# Altium schematic sheet LCD_Interface.SchDoc
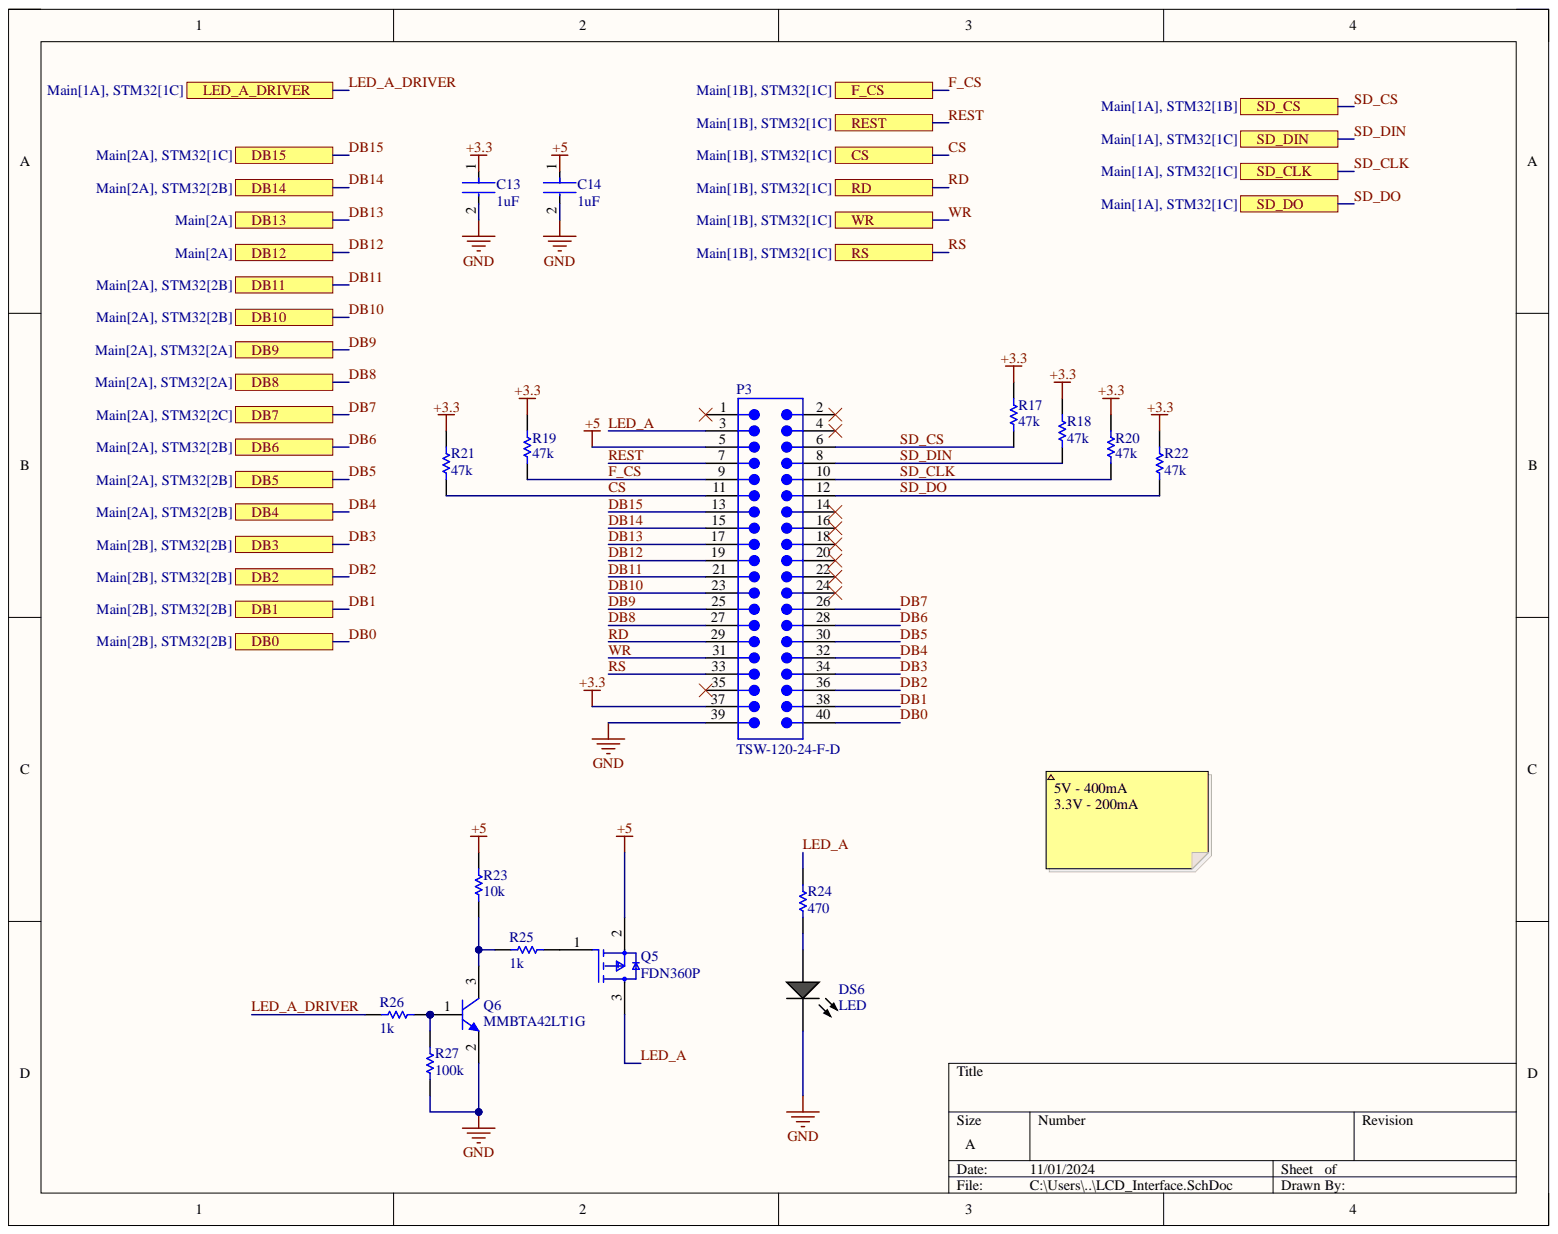
[diagram p0: LCD_Interface.SchDoc]

## Parts
C13  1uF  [FP-0805-L_2_01_0_2-W_1_25-IPC_B]
C14  1uF  [FP-0805-L_2_01_0_2-W_1_25-IPC_B]
DS6  LED  [AVAG-HSMX-C170_V]
P3  TSW-120-24-F-D  [SMTC-TSW-120-24-X-D]
Q5  FDN360P  [FP-527AG-IPC_B]
Q6  MMBTA42LT1G  [ONSC-SOT-23-3-318-08_V]
R17  47k  [RESC2013X50X30LL20T20]
R18  47k  [RESC2013X50X30LL20T20]
R19  47k  [RESC2013X50X30LL20T20]
R20  47k  [RESC2013X50X30LL20T20]
R21  47k  [RESC2013X50X30LL20T20]
R22  47k  [RESC2013X50X30LL20T20]
R23  10k  [RESC2013X50X30LL20T20]
R24  470  [RESC2013X50X30LL20T20]
R25  1k  [RESC2013X50X30LL20T20]
R26  1k  [RESC2013X50X30LL20T20]
R27  100k  [RESC2013X50X30LL20T20]

## Ports
CS, DB0, DB1, DB2, DB3, DB4, DB5, DB6, DB7, DB8, DB9, DB10, DB11, DB12, DB13, DB14, DB15, F_CS, LED_A_DRIVER, RD, REST, RS, SD_CLK, SD_CS, SD_DIN, SD_DO, WR

## Nets
+3.3: C13.1 P3.37 R17.2 R18.2 R19.2 R20.2 R21.2 R22.2
+5: C14.1 P3.5 Q5.2 R23.2
GND: C13.2 C14.2 DS6.2 P3.39 Q6.2 R27.1
CS: P3.11 R21.1 ; port CS
DB0: P3.40 ; port DB0
DB1: P3.38 ; port DB1
DB2: P3.36 ; port DB2
DB3: P3.34 ; port DB3
DB4: P3.32 ; port DB4
DB5: P3.30 ; port DB5
DB6: P3.28 ; port DB6
DB7: P3.26 ; port DB7
DB8: P3.27 ; port DB8
DB9: P3.25 ; port DB9
DB10: P3.23 ; port DB10
DB11: P3.21 ; port DB11
DB12: P3.19 ; port DB12
DB13: P3.17 ; port DB13
DB14: P3.15 ; port DB14
DB15: P3.13 ; port DB15
F_CS: P3.9 R19.1 ; port F_CS
LED_A: P3.3 Q5.3 R24.2
LED_A_DRIVER: R26.1 ; port LED_A_DRIVER
RD: P3.29 ; port RD
REST: P3.7 ; port REST
RS: P3.33 ; port RS
SD_CLK: P3.10 R20.1 ; port SD_CLK
SD_CS: P3.6 R17.1 ; port SD_CS
SD_DIN: P3.8 R18.1 ; port SD_DIN
SD_DO: P3.12 R22.1 ; port SD_DO
WR: P3.31 ; port WR
NetDS6_1: DS6.1 R24.1
NetP3_1: P3.1
NetP3_2: P3.2
NetP3_4: P3.4
NetP3_14: P3.14
NetP3_16: P3.16
NetP3_18: P3.18
NetP3_20: P3.20
NetP3_22: P3.22
NetP3_24: P3.24
NetP3_35: P3.35
NetQ5_1: Q5.1 R25.2
NetQ6_1: Q6.1 R26.2 R27.2
NetQ6_3: Q6.3 R23.1 R25.1

## Library data of the parts
C13 C14 = CMP-2007-04174-2: General Purpose Ceramic Capacitor, 0805, 100nF, 10%, X7R, 15%, 100V; Capacitance: 100nF; Case Code (Imperial): 0805; Case Code (Metric): 2012; Case/Package: 0805; Component Kind: Standard; Construction: Flat; Contact Plating: Tin; Depth: 1.25mm; Dielectric: X7R; Dielectric Material: Ceramic; Height: 1.4mm; Lead Free: Lead Free; Length: 2.01mm; Library Name: Altium Content Vault; Max Operating Temperature: 125°C; Min Operating Temperature: -55°C; Mount: Surface Mount; Number of Pins: 2; Package Quantity: 2000; Packaging: Tape and Reel; Radiation Hardening: No; REACH SVHC: No SVHC; RoHS Compliant: Yes; Series: -; Termination: SMD/SMT; Thickness: 0.055inch; Tolerance: 10%; Voltage: 100V; Voltage Rating: 100V; Voltage Rating (DC): 100V; Width: 0.049inch
DS6 = CMP-2000-05062-1: Chip LED, Green, 2.2 V, -40 to 85 degC, 2-Pin SMD, Pb-Free, Tape and Reel; Manufacturer: Avago; Bulb Size: 1.25mmx1.4mm; Dominant Wavelength: 572nm; External Depth: 0.8mm; External Length / Height: 2mm; External Width: 1.25mm; Forward Current If: 20mA; Forward Voltage: 2.2V; Forward Voltage VF Max: 2.6V; LED Type: Standard; Lens Shape: Rectangular; Light Area Height: 1mm; Luminous Intensity: 15mcd; Luminous Intensity Min: 4mcd; No. of Pins: 2; Opto Mounting Orientation: Top; Peak Wavelength: 570nm; PIV Max: 5V; SVHC: NoSVHC(16-Jun-2014); Viewing Angle: 170deg; Component Kind: Standard; Datasheet URL: https://datasheet.ciiva.com/29280/180495-da-01-en-chip-led-hsmg-c170-gelb-gruen-29280971.pdf; Datasheet Version: Oct-08; Dominant Wavelength Typ: 572nm; Forward Current If Max: 20mA; LED Colour: Green; LED Mounting: SMD; Library Name: Altium Content Vault; Luminous Intensity Typ: 15mcd; Manufacturer URL: http://www.avagotech.com/; Mounting Type: SMD; MSL: MSL2A-4weeks; Operating Temperature Max: 85degC; Operating Temperature Min: -30degC; Operating Temperature Range: -30degCto+85degC; Opto Case Style: SMD; Package Description: 2-Pin Surface Mount Device, Body 2 x 1.25 mm, Height 0.8 mm; Package Reference: HSMX-C170; Packaging: CutTape; Peak Forward Current: 20mA; Storage Temperature Max: 85degC; Storage Temperature Min: -40degC; Voltage Vf Typ: 2.2V; Wavelength Typ: 570nm
P3 = CMP-1024-00453-1: 0.025" SQ Post Header, Through-hole, Vertical, -55 to 125 degC, 2.54 mm Pitch, 40-Pin, Male, RoHS; Manufacturer: Samtec; Pitch: 2.54 mm; Component Kind: Standard; ComponentLink1Description: Manufacturer URL; ComponentLink1URL: http://www.samtec.com; ComponentLink2Description: Datasheet; ComponentLink2URL: http://www.samtec.com/documents/webfiles/pdf/TSW_TH.PDF; ComponentLink3Description: Package Specification; ComponentLink3URL: http://www.samtec.com/documents/webfiles/cpdf/TSW-XXX-XX-XXX-X-XX-XXX-MKT.pdf; DatasheetVersion: F-214; Library Name: Altium Content Vault; Mounting Technology: Through-hole; Orientation: Vertical; PackageDescription: .025[0.64] SQ. Post Terminal Strip Assembly; PackageReference: TSW-120-24-X-D; PackageVersion: DM, 8/1988; PartNumber: TSW-120-24-F-D; RoHS: True; Temperature Range: -55 to 125 degC
Q5 = CMP-2000-07455-3: MOSFET P-CH 30V 2A SSOT3; Current Rating: -2A; Drain to Source Breakdown Voltage: -30V; Drain to Source Resistance: 63mR; Element Configuration: Single; Fall Time: 6ns; Gate to Source Voltage (Vgs): 20V; Input Capacitance: 298pF; Max Power Dissipation: 500mW; Number of Elements: 1; On-State Resistance: 80MR; Rise Time: 13ns; Turn-Off Delay Time: 11ns; Case/Package: SOT-23; Component Kind: Standard; Contact Plating: Tin; Continuous Drain Current (ID): 2A; Drain to Source Voltage (Vdss): 30V; Dual Supply Voltage: -30V; Height: 0.94mm; Lead Free: Lead Free; Length: 2.92mm; Library Name: Altium Content Vault; Max Operating Temperature: 150°C; Min Operating Temperature: -55°C; Mount: Surface Mount; Number of Pins: 3; Package Quantity: 1; Packaging: Tape and Reel; Power Dissipation: 500mW; Radiation Hardening: No; Rds On Max: 80mR; REACH SVHC: No SVHC; Threshold Voltage: 20V; Turn-On Delay Time: 6ns; Voltage Rating (DC): -30V; Weight: 0.03g; Width: 1.4mm
Q6 = CMP-1048-00662-1: High Voltage Transistor, NPN Silicon, 3-Pin SOT-23, Pb-Free, Tape and Reel; Manufacturer: On Semiconductor; fT Min (MHz): 50; IC Continuous (A): 0.5; Component Kind: Standard; ComponentLink1Description: Manufacturer URL; ComponentLink1URL: http://www.onsemi.com/; ComponentLink2Description: Datasheet; ComponentLink2URL: http://www.onsemi.cn/pub_link/Collateral/MMBTA42LT1-D.PDF; ComponentLink3Description: Package Specification; ComponentLink3URL: http://www.onsemi.cn/pub_link/Collateral/318-08.PDF; DatasheetVersion: Rev. 12; Library Name: Altium Content Vault; Mounting Technology: Surface Mount; PackageDescription: 3-Pin Small Outline Transistor, Body 2.92 x 1.3 mm, Pitch 0.95 mm; PackageReference: SOT-23-3-318-08; PackageVersion: Rev. AP, 11/2009; Packing: Tape and Reel; PartNumber: MMBTA42LT1G; Polarity: NPN; RoHS: TRUE
R17-R27 = CMP-2001-04896-1: Manufacturer: Vishay Dale; Part Number: CRCW08050000Z0EA; Component Kind: Standard; Library Name: Altium Content Vault
